# Altium schematic sheet Magnetic Rotary encoder.SchDoc
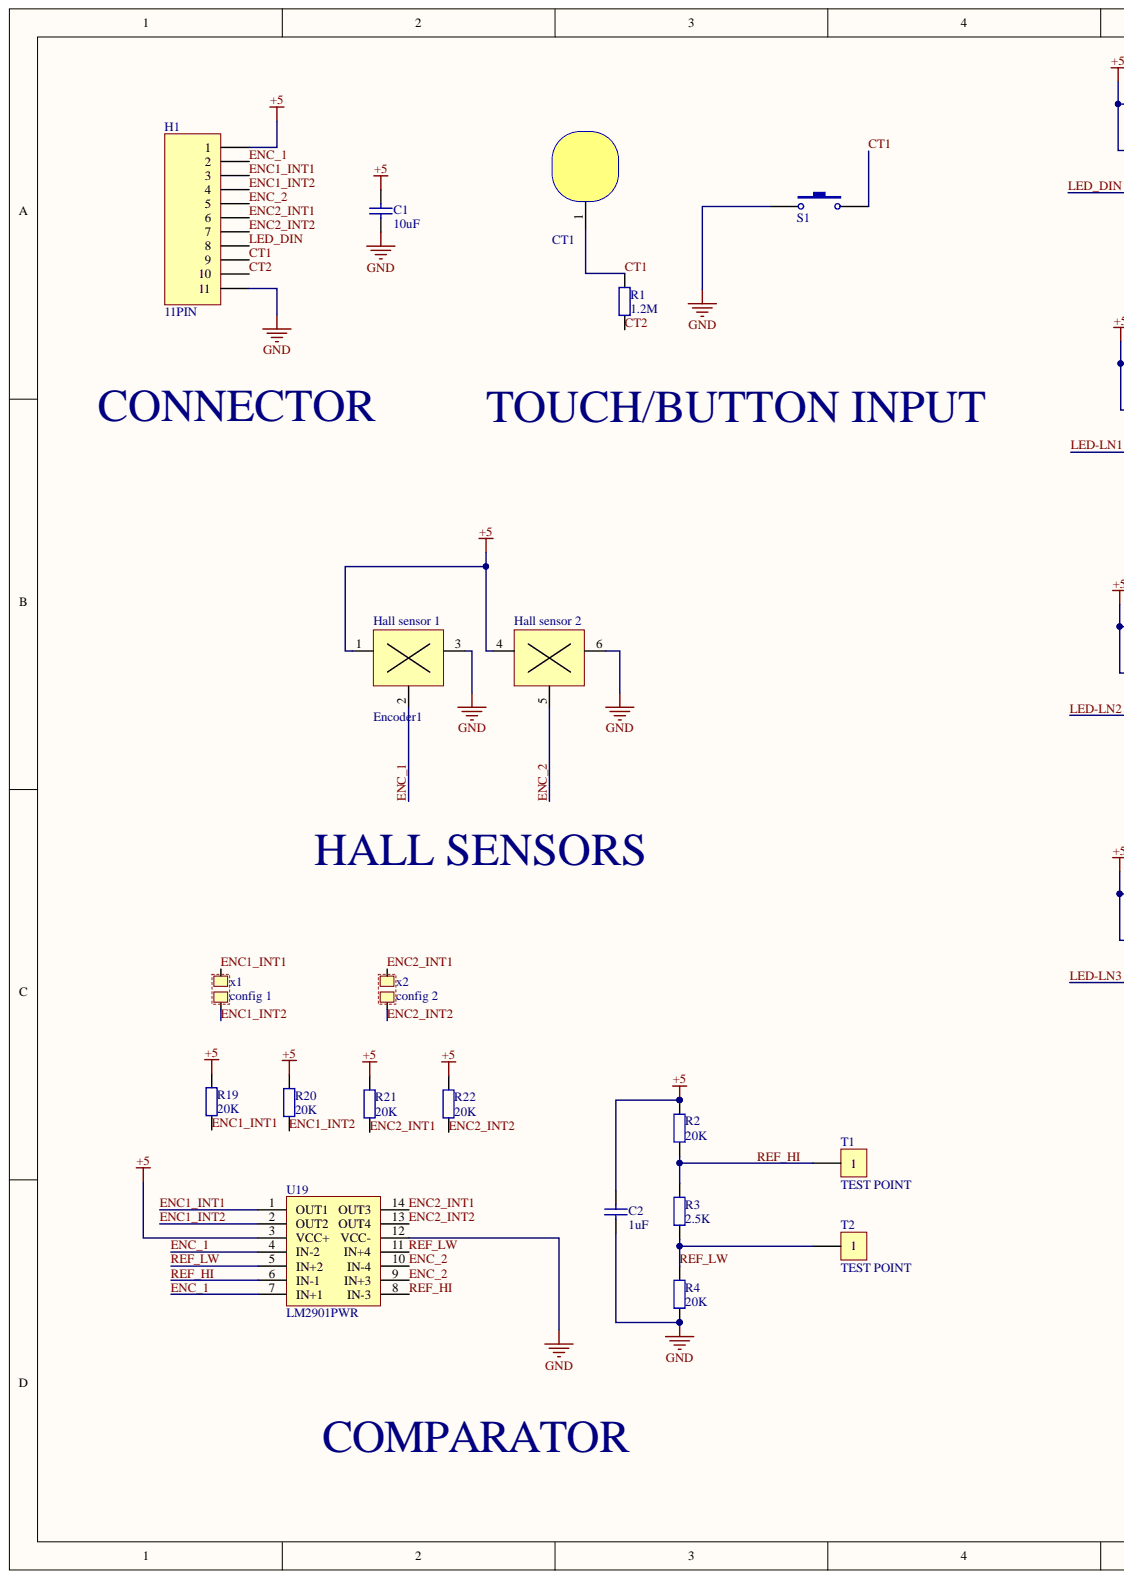
[diagram p0: Magnetic Rotary encoder.SchDoc, left]
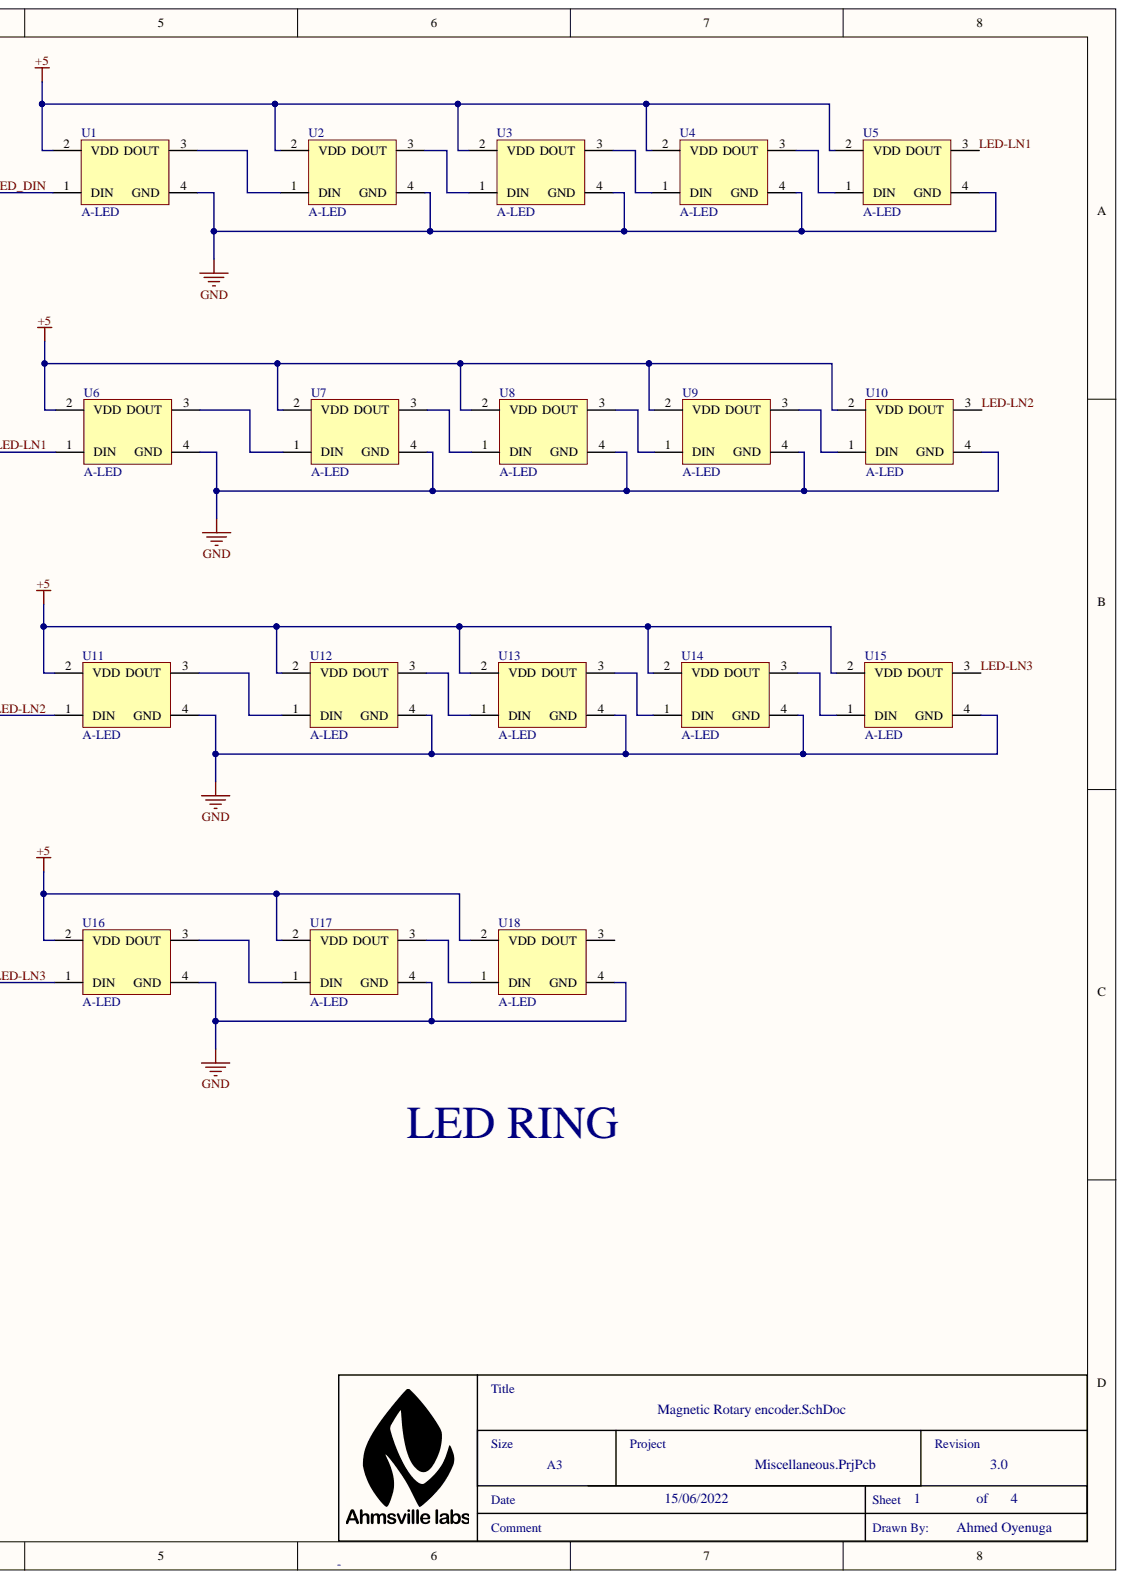
[diagram p1: Magnetic Rotary encoder.SchDoc, right]

## Parts
C1  value 10uF  [0805 cap]  (hidden comment: Cap)
C2  value 1uF  [0603 cap]  (hidden comment: Cap)
CT1  Touch pad  [Ahmsville dial v.2 cap touch]  (value not drawn)
Encoder1  Rotary encoder (SMD Hall sensor)  [MAG ENC SMD - 72 ENCODER]  (value not drawn)
H1  11PIN  [HEADER 11PIN]
R1  value 1.2M  [0603 res]  (hidden comment: Res)
R2  value 20K  [0402 RES]  (hidden comment: Res)
R3  value 2.5K  [0402 RES]  (hidden comment: Res)
R4  value 20K  [0402 RES]  (hidden comment: Res)
R19  value 20K  [0402 RES]  (hidden comment: Res)
R20  value 20K  [0402 RES]  (hidden comment: Res)
R21  value 20K  [0402 RES]  (hidden comment: Res)
R22  value 20K  [0402 RES]  (hidden comment: Res)
S1  Push button  [PUSH BUTTON-4.5x4.5mm TH]  (value not drawn)
T1  TEST POINT  [HEADER 1PIN]
T2  TEST POINT  [HEADER 1PIN]
U1  A-LED  [SK6812 SIDE]
U2  A-LED  [SK6812 SIDE]
U3  A-LED  [SK6812 SIDE]
U4  A-LED  [SK6812 SIDE]
U5  A-LED  [SK6812 SIDE]
U6  A-LED  [SK6812 SIDE]
U7  A-LED  [SK6812 SIDE]
U8  A-LED  [SK6812 SIDE]
U9  A-LED  [SK6812 SIDE]
U10  A-LED  [SK6812 SIDE]
U11  A-LED  [SK6812 SIDE]
U12  A-LED  [SK6812 SIDE]
U13  A-LED  [SK6812 SIDE]
U14  A-LED  [SK6812 SIDE]
U15  A-LED  [SK6812 SIDE]
U16  A-LED  [SK6812 SIDE]
U17  A-LED  [SK6812 SIDE]
U18  A-LED  [SK6812 SIDE]
U19  LM2901PWR  [TSSOP14]
x1  Config Pads  [CONFIG PAD]  (value not drawn)
x2  Config Pads  [CONFIG PAD]  (value not drawn)

## Nets
+5: C1.2 C2.2 Encoder1.1 Encoder1.4 H1.1 R2.2 R19.2 R20.2 R21.2 R22.2 U1.2(VDD) U2.2(VDD) U3.2(VDD) U4.2(VDD) U5.2(VDD) U6.2(VDD) U7.2(VDD) U8.2(VDD) U9.2(VDD) U10.2(VDD) U11.2(VDD) U12.2(VDD) U13.2(VDD) U14.2(VDD) U15.2(VDD) U16.2(VDD) U17.2(VDD) U18.2(VDD) U19.3(VCC+)
GND: C1.1 C2.1 Encoder1.3 Encoder1.6 H1.11 R4.1 S1.1 U1.4(GND) U2.4(GND) U3.4(GND) U4.4(GND) U5.4(GND) U6.4(GND) U7.4(GND) U8.4(GND) U9.4(GND) U10.4(GND) U11.4(GND) U12.4(GND) U13.4(GND) U14.4(GND) U15.4(GND) U16.4(GND) U17.4(GND) U18.4(GND) U19.12(VCC-)
CT1: CT1.1 H1.9 R1.2 S1.2
CT2: H1.10 R1.1
ENC1_INT1: H1.3 R19.1 U19.1(OUT1) x1.1
ENC1_INT2: H1.4 R20.1 U19.2(OUT2) x1.2
ENC2_INT1: H1.6 R21.1 U19.14(OUT3) x2.1
ENC2_INT2: H1.7 R22.1 U19.13(OUT4) x2.2
ENC_1: Encoder1.2 H1.2 U19.4(IN-2) U19.7(IN+1)
ENC_2: Encoder1.5 H1.5 U19.9(IN+3) U19.10(IN-4)
LED-LN1: U5.3(DOUT) U6.1(DIN)
LED-LN2: U10.3(DOUT) U11.1(DIN)
LED-LN3: U15.3(DOUT) U16.1(DIN)
LED_DIN: H1.8 U1.1(DIN)
REF_HI: R2.1 R3.2 T1.1 U19.6(IN-1) U19.8(IN-3)
REF_LW: R3.1 R4.2 T2.1 U19.5(IN+2) U19.11(IN+4)
NetU1_3: U1.3(DOUT) U2.1(DIN)
NetU2_3: U2.3(DOUT) U3.1(DIN)
NetU3_3: U3.3(DOUT) U4.1(DIN)
NetU4_3: U4.3(DOUT) U5.1(DIN)
NetU6_3: U6.3(DOUT) U7.1(DIN)
NetU7_3: U7.3(DOUT) U8.1(DIN)
NetU8_3: U8.3(DOUT) U9.1(DIN)
NetU9_3: U9.3(DOUT) U10.1(DIN)
NetU11_3: U11.3(DOUT) U12.1(DIN)
NetU12_3: U12.3(DOUT) U13.1(DIN)
NetU13_3: U13.3(DOUT) U14.1(DIN)
NetU14_3: U14.3(DOUT) U15.1(DIN)
NetU16_3: U16.3(DOUT) U17.1(DIN)
NetU17_3: U17.3(DOUT) U18.1(DIN)

Not connected: U18 3(DOUT)

## Library data of the parts
C1 C2 = Cap: Capacitor; Component Kind: Standard; LatestRevisionDate: 17-Jul-2002; LatestRevisionNote: Re-released for DXP Platform.; Library Name: My Altium libary.IntLib; PackageDescription: Radial Cap, Thru-Hole; 2 Leads; 0.3 in Pin Spacing; PackageReference: RAD-0.3; Published: 8-Jun-2000; Supplier 1: lcsc.com; Supplier 2: aliexpress.com; Supplier Unit Price 1: 0.03; Supplier Unit Price 2: 0.03; Publisher: Altium Limited
CT1 = Touch pad: Capacitive touch pad; Component Kind: Standard; Library Name: My Altium libary.IntLib
Encoder1 = Rotary encoder (SMD Hall sensor): Rotary encoder (SMD Hall sensor) SOT23; Component Kind: Standard; Library Name: My Altium libary.IntLib; Supplier 1: lcsc.com; Supplier Unit Price 1: 0.44
H1 = 11PIN: Header 11PIN; Component Kind: Standard; LatestRevisionDate: 17-Jul-2002; LatestRevisionNote: Re-released for DXP Platform.; Library Name: My Altium libary.IntLib; Publisher: Altium Limited
R1-R4 R19-R22 = Res: Resistor; Component Kind: Standard; LatestRevisionDate: 17-Jul-2002; LatestRevisionNote: Re-released for DXP Platform.; Library Name: My Altium libary.IntLib; PackageDescription: Axial Device, Thru-Hole; 2 Leads; 0.4 in Pin Spacing; PackageReference: AXIAL-0.4; Published: 8-Jun-2000; Supplier 1: lcsc.com; Supplier 2: aliexpress.com; Supplier Unit Price 1: 0.0046; Supplier Unit Price 2: 0.01; Publisher: Altium Limited
S1 = Push button: Push button (C318895); Component Kind: Standard; LatestRevisionDate: 17-Jul-2002; LatestRevisionNote: Re-released for DXP Platform.; Library Name: My Altium libary.IntLib; PackageDescription: Switch; 2 Leads; PackageReference: SPST-2; Published: 24-Mar-1999; Publisher: Altium Limited
U1-U18 = SK6812: SK6812 SIDE-A Addressable led; Component Kind: Standard; DatasheetVersion: 16-Apr-2002; LatestRevisionDate: 17-Dec-2003; LatestRevisionNote: PCB Footprint replaced.; Library Name: My Altium libary.IntLib; PackageDescription: SM LED; 2 C-Bend Leads; Body 3.5 x 2.8 x 1.9 mm, inc leads (LxWxH typ); PackageReference: 3.5X2.8X1.9; PackageVersion: 16-Apr-2002; Published: 8-Jun-2000; Publisher: Altium Limited
U19 = LM2901PWR: Low-power quad voltage comparator; Component Kind: Standard; Library Name: My Altium libary.IntLib
x1 = Config Pads: PCB Function Configuration Pads; Function: config 1; Component Kind: Standard; Library Name: My Altium libary.IntLib
x2 = Config Pads: PCB Function Configuration Pads; Function: config 2; Component Kind: Standard; Library Name: My Altium libary.IntLib
T1 T2 = TEST POINT: Component Kind: Standard; Description: TEST POINT; LatestRevisionDate: 17-Jul-2002; LatestRevisionNote: Re-released for DXP Platform.; Library Name: My Altium libary.IntLib; Publisher: Altium Limited
